# Altium schematic sheet OpenThereminUNO2.0.SchDoc
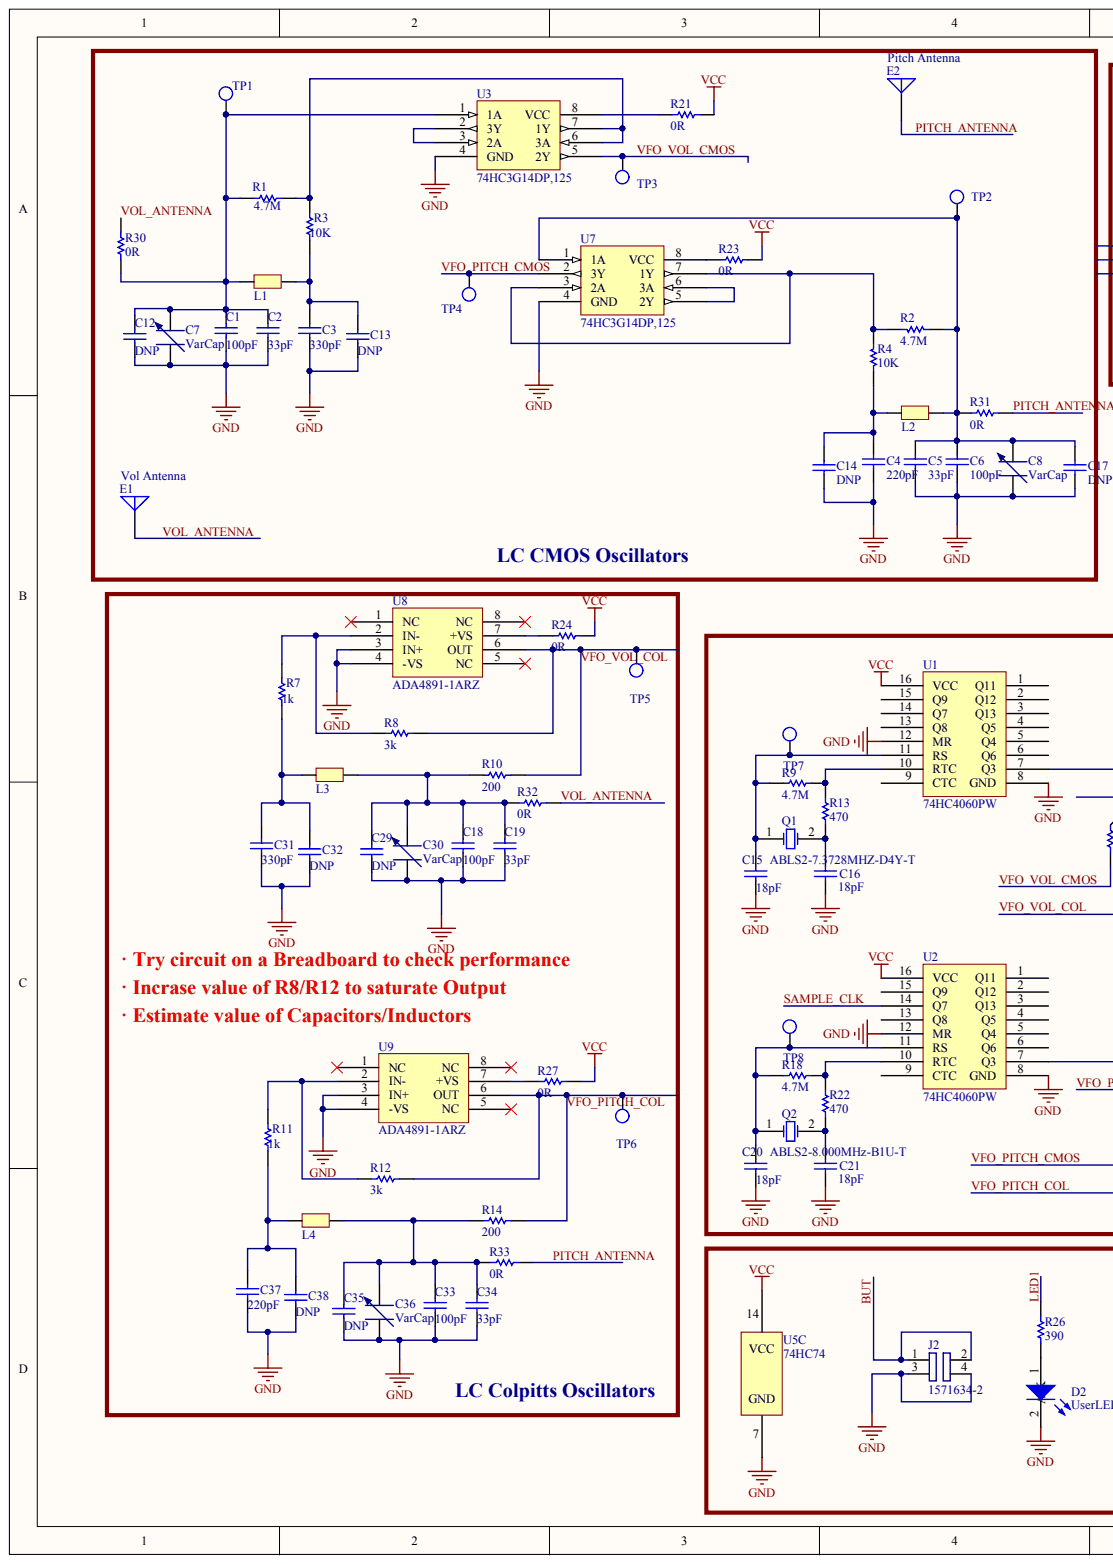
[diagram p0: OpenThereminUNO2.0.SchDoc, left]
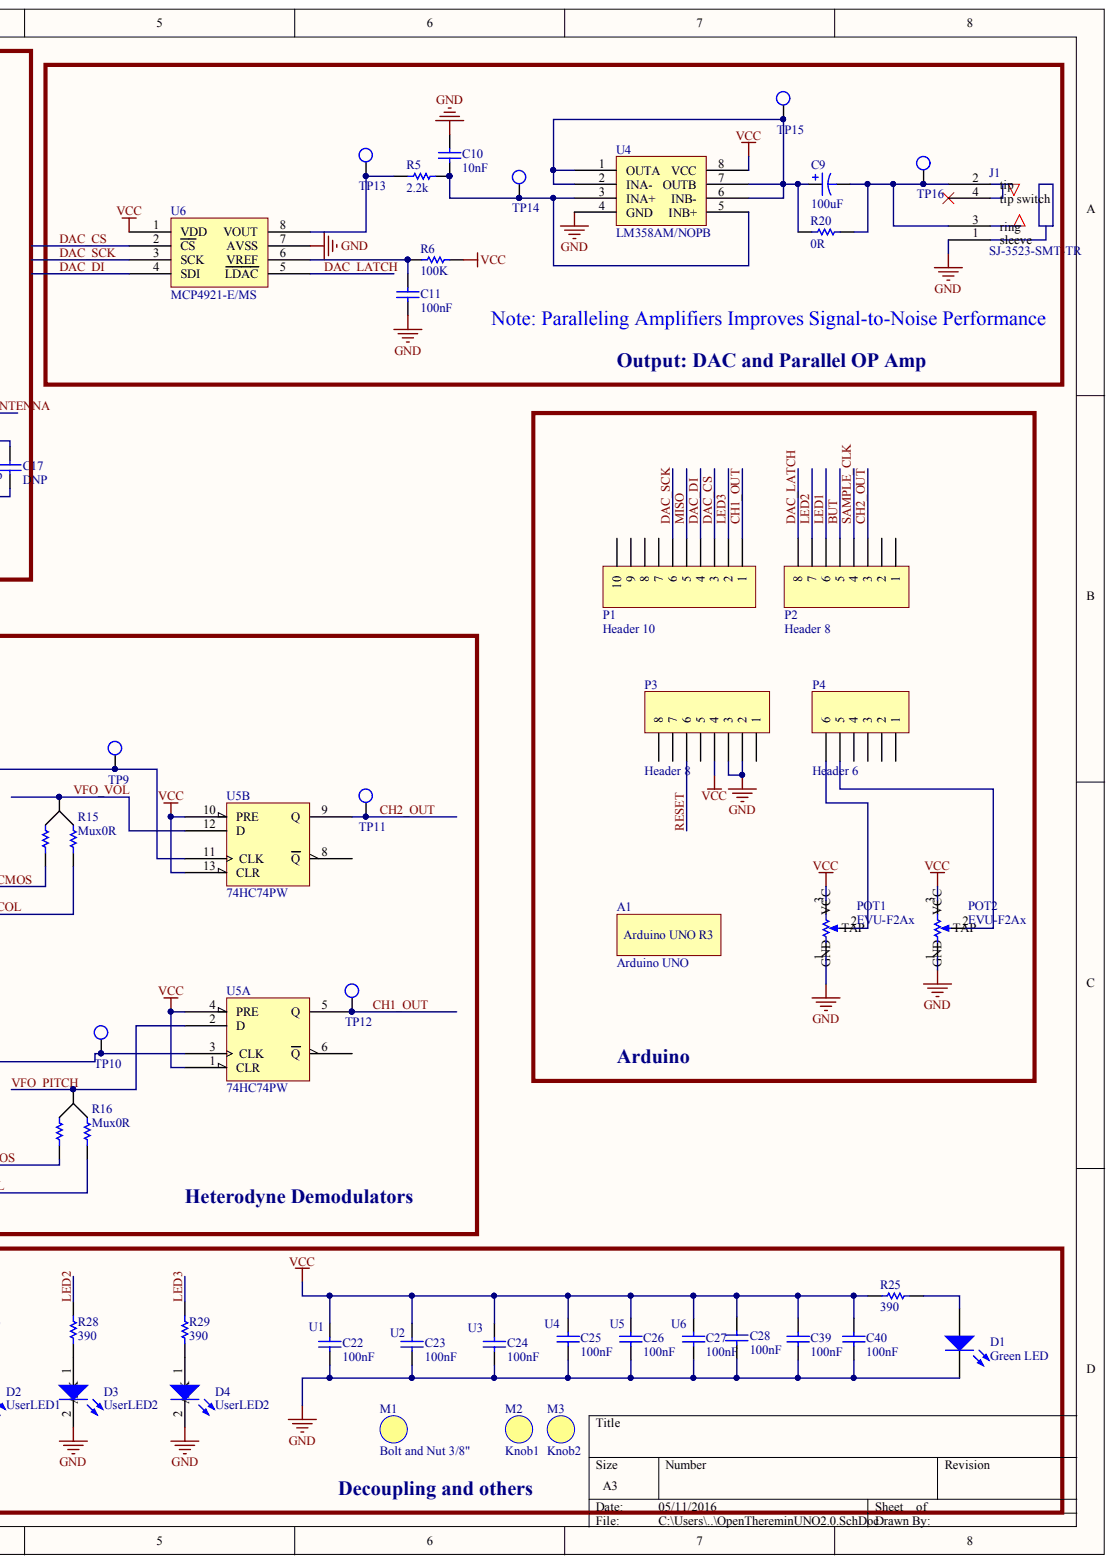
[diagram p1: OpenThereminUNO2.0.SchDoc, right]

## Parts
A1  Arduino UNO  [ArduinoUNO]
C1  value 100pF  [0603_CAP]  (hidden comment: Cap)
C2  value 33pF  [0603_CAP]  (hidden comment: Cap)
C3  value 330pF  [0603_CAP]  (hidden comment: Cap)
C4  value 220pF  [0603_CAP]  (hidden comment: Cap)
C5  value 33pF  [0603_CAP]  (hidden comment: Cap)
C6  value 100pF  [0603_CAP]  (hidden comment: Cap)
C7  VarCap  [VarCapacitor]
C8  VarCap  [VarCapacitor]
C9  value 100uF  [TANTALUM_CAP]  (hidden comment: Cap Pol1)
C10  value 10nF  [0603_CAP]  (hidden comment: Cap)
C11  value 100nF  [0603_CAP]  (hidden comment: Cap)
C12  value DNP  [0603_CAP]  (hidden comment: Cap)
C13  value DNP  [0603_CAP]  (hidden comment: Cap)
C14  value DNP  [0603_CAP]  (hidden comment: Cap)
C15  value 18pF  [0603_CAP]  (hidden comment: Cap)
C16  value 18pF  [0603_CAP]  (hidden comment: Cap)
C17  value DNP  [0603_CAP]  (hidden comment: Cap)
C18  value 100pF  [0603_CAP]  (hidden comment: Cap)
C19  value 33pF  [0603_CAP]  (hidden comment: Cap)
C20  value 18pF  [0603_CAP]  (hidden comment: Cap)
C21  value 18pF  [0603_CAP]  (hidden comment: Cap)
C22  value 100nF  [0603_CAP]  (hidden comment: Cap)
C23  value 100nF  [0603_CAP]  (hidden comment: Cap)
C24  value 100nF  [0603_CAP]  (hidden comment: Cap)
C25  value 100nF  [0603_CAP]  (hidden comment: Cap)
C26  value 100nF  [0603_CAP]  (hidden comment: Cap)
C27  value 100nF  [0603_CAP]  (hidden comment: Cap)
C28  value 100nF  [0603_CAP]  (hidden comment: Cap)
C29  value DNP  [0603_CAP]  (hidden comment: Cap)
C30  VarCap  [VarCapacitor]
C31  value 330pF  [0603_CAP]  (hidden comment: Cap)
C32  value DNP  [0603_CAP]  (hidden comment: Cap)
C33  value 100pF  [0603_CAP]  (hidden comment: Cap)
C34  value 33pF  [0603_CAP]  (hidden comment: Cap)
C35  value DNP  [0603_CAP]  (hidden comment: Cap)
C36  VarCap  [VarCapacitor]
C37  value 220pF  [0603_CAP]  (hidden comment: Cap)
C38  value DNP  [0603_CAP]  (hidden comment: Cap)
C39  value 100nF  [0603_CAP]  (hidden comment: Cap)
C40  value 100nF  [0603_CAP]  (hidden comment: Cap)
D1  Green LED  [LED]
D2  UserLED1  [LED]
D3  UserLED2  [LED]
D4  UserLED2  [LED]
E1  Vol Antenna  [ANTENNA]
E2  Pitch Antenna  [ANTENNA]
J1  SJ-3523-SMT-TR  [SJ-352x-SMT-Series]
J2  1571634-2  [BUTTON_SMD]
L1  Inductor  [INDUCTOR_BADFP]
L2  Inductor  [INDUCTOR_BADFP]
L3  Inductor  [INDUCTOR_BADFP]
L4  Inductor  [INDUCTOR_BADFP]
M1  Bolt and Nut 3/8"  [BOLT_NUT_3_8]
M2  Knob1  [KNOB]
M3  Knob2  [KNOB]
P1  Header 10  [HEADER1X10]
P2  Header 8  [HEADER1X8]
P3  Header 8  [HEADER1X8]
P4  Header 6  [HEADER1X6]
POT1  EVU-F2Ax  [EVUF2A]
POT2  EVU-F2Ax  [EVUF2A]
Q1  ABLS2-7.3728MHZ-D4Y-T  [SMD_CRYSTAL]
Q2  ABLS2-8.000MHz-B1U-T  [SMD_CRYSTAL]
R1  value 4.7M  [0603_RES]  (hidden comment: Res1)
R2  value 4.7M  [0603_RES]  (hidden comment: Res1)
R3  value 10K  [0603_RES]  (hidden comment: Res1)
R4  value 10K  [0603_RES]  (hidden comment: Res1)
R5  value 2.2k  [0603_RES]  (hidden comment: Res1)
R6  value 100K  [0603_RES]  (hidden comment: Res1)
R7  value 1k  [0603_RES]  (hidden comment: Res1)
R8  value 3k  [0603_RES]  (hidden comment: Res1)
R9  value 4.7M  [0603_RES]  (hidden comment: Res1)
R10  value 200  [0603_RES]  (hidden comment: Res1)
R11  value 1k  [0603_RES]  (hidden comment: Res1)
R12  value 3k  [0603_RES]  (hidden comment: Res1)
R13  value 470  [0603_RES]  (hidden comment: Res1)
R14  value 200  [0603_RES]  (hidden comment: Res1)
R15  Mux0R  [MUX0R]
R16  Mux0R  [MUX0R]
R18  value 4.7M  [0603_RES]  (hidden comment: Res1)
R20  value 0R  [0603_RES]  (hidden comment: Res1)
R21  value 0R  [0603_RES]  (hidden comment: Res1)
R22  value 470  [0603_RES]  (hidden comment: Res1)
R23  value 0R  [0603_RES]  (hidden comment: Res1)
R24  value 0R  [0603_RES]  (hidden comment: Res1)
R25  value 390  [0603_RES]  (hidden comment: Res1)
R26  value 390  [0603_RES]  (hidden comment: Res1)
R27  value 0R  [0603_RES]  (hidden comment: Res1)
R28  value 390  [0603_RES]  (hidden comment: Res1)
R29  value 390  [0603_RES]  (hidden comment: Res1)
R30  value 0R  [0603_RES]  (hidden comment: Res1)
R31  value 0R  [0603_RES]  (hidden comment: Res1)
R32  value 0R  [0603_RES]  (hidden comment: Res1)
R33  value 0R  [0603_RES]  (hidden comment: Res1)
TP1  TestPoint  [TEST_POINT_VIA]  (value not drawn)
TP2  TestPoint  [TEST_POINT_VIA]  (value not drawn)
TP3  TestPoint  [TEST_POINT_VIA]  (value not drawn)
TP4  TestPoint  [TEST_POINT_VIA]  (value not drawn)
TP5  TestPoint  [TEST_POINT_VIA]  (value not drawn)
TP6  TestPoint  [TEST_POINT_VIA]  (value not drawn)
TP7  TestPoint  [TEST_POINT_VIA]  (value not drawn)
TP8  TestPoint  [TEST_POINT_VIA]  (value not drawn)
TP9  TestPoint  [TEST_POINT_VIA]  (value not drawn)
TP10  TestPoint  [TEST_POINT_VIA]  (value not drawn)
TP11  TestPoint  [TEST_POINT_VIA]  (value not drawn)
TP12  TestPoint  [TEST_POINT_VIA]  (value not drawn)
TP13  TestPoint  [TEST_POINT_VIA]  (value not drawn)
TP14  TestPoint  [TEST_POINT]  (value not drawn)
TP15  TestPoint  [TEST_POINT]  (value not drawn)
TP16  TestPoint  [TEST_POINT]  (value not drawn)
U1  74HC4060PW  [74HC4060_TSSOP16]
U2  74HC4060PW  [74HC4060_TSSOP16]
U3  74HC3G14DP,125  [74HC3G14DP,125]
U4  LM358AM/NOPB  [LM358_SOIC8]
U5  74HC74PW  [74HC74_TSSOP14]  (parts A,B,C)
U6  MCP4921-E/MS  [MC4921_MSOP]
U7  74HC3G14DP,125  [74HC3G14DP,125]
U8  ADA4891-1ARZ  [ADA4891-1]
U9  ADA4891-1ARZ  [ADA4891-1]

## Nets
GND: C1.1 C2.1 C3.1 C4.1 C5.1 C6.1 C7.1 C8.1 C10.2 C11.1 C12.1 C13.1 C14.1 C15.1 C16.1 C17.1 C18.1 C19.1 C20.1 C21.1 C22.1 C23.1 C24.1 C25.1 C26.1 C27.1 C28.1 C29.1 C30.1 C31.1 C32.1 C33.1 C34.1 C35.1 C36.1 C37.1 C38.1 C39.1 C40.1 D1.2 D2.2 D3.2 D4.2 J1.1(sleeve) J2.3 J2.4 P3.2 P3.3 POT1.1 POT2.1 U1.8(GND) U1.12(MR) U2.8(GND) U2.12(MR) U3.4(GND) U4.4(GND) U5.7 U6.7(AVSS) U7.4(GND) U8.3(IN+) U8.4(-VS) U9.3(IN+) U9.4(-VS)
VCC: C22.2 C23.2 C24.2 C25.2 C26.2 C27.2 C28.2 C39.2 C40.2 P3.4 POT1.3 POT2.3 R6.1 R21.1 R23.1 R24.1 R25.1 R27.1 U1.16(VCC) U2.16(VCC) U4.8(VCC) U5.1(CLR) U5.4(PRE) U5.10(PRE) U5.13(CLR) U5.14 U6.1(VDD)
BUT: J2.1 J2.2 P2.5
CH1_OUT: P1.1 TP12.1 U5.5(Q)
CH2_OUT: P2.3 TP11.1 U5.9(Q)
DAC_CS: P1.3 U6.2(CS)
DAC_DI: P1.4 U6.4(SDI)
DAC_LATCH: P2.8 U6.5(LDAC)
DAC_SCK: P1.6 U6.3(SCK)
LED1: P2.6 R26.2
LED2: P2.7 R28.2
LED3: P1.2 R29.2
MISO: P1.5
PITCH_ANTENNA: E2.1 R31.1 R33.1
RESET: P3.6
SAMPLE_CLK: P2.4 U2.14(Q7)
VFO_PITCH: R16.3 U5.2(D)
VFO_PITCH_CMOS: R16.1 TP4.1 U7.2(3Y)
VFO_PITCH_COL: R12.2 R14.2 R16.2 TP6.1 U9.6(OUT)
VFO_VOL: R15.3 U5.12(D)
VFO_VOL_CMOS: R15.1 TP3.1 U3.5(2Y)
VFO_VOL_COL: R8.2 R10.2 R15.2 TP5.1 U8.6(OUT)
VOL_ANTENNA: E1.1 R30.1 R32.1
NetC1_2: C1.2 C2.2 C7.2 C12.2 L1.1 R1.1 R30.2 TP1.1 U3.1(1A)
NetC3_2: C3.2 C13.2 L1.2 R3.1
NetC4_2: C4.2 C14.2 L2.1 R4.1
NetC5_2: C5.2 C6.2 C8.2 C17.2 L2.2 R2.1 R31.2 TP2.1 U7.1(1A)
NetC9_1: C9.1 R20.2 TP15.1 U4.1(OUTA) U4.2(INA-) U4.6(INB-) U4.7(OUTB)
NetC9_2: C9.2 J1.2(tip) J1.3(ring) R20.1 TP16.1
NetC10_1: C10.1 R5.2 TP14.1 U4.3(INA+) U4.5(INB+)
NetC11_2: C11.2 R6.2 U6.6(VREF)
NetC15_2: C15.2 Q1.1 R9.2 TP7.1 U1.11(RS)
NetC16_2: C16.2 Q1.2 R13.1
NetC18_2: C18.2 C19.2 C29.2 C30.2 L3.1 R10.1 R32.2
NetC20_2: C20.2 Q2.1 R18.2 TP8.1 U2.11(RS)
NetC21_2: C21.2 Q2.2 R22.1
NetC31_2: C31.2 C32.2 L3.2 R7.1
NetC33_2: C33.2 C34.2 C35.2 C36.2 L4.1 R14.1 R33.2
NetC37_2: C37.2 C38.2 L4.2 R11.1
NetD1_1: D1.1 R25.2
NetD2_1: D2.1 R26.1
NetD3_1: D3.1 R28.1
NetD4_1: D4.1 R29.1
NetP4_5: P4.5 POT2.2
NetP4_6: P4.6 POT1.2
NetR1_2: R1.2 R3.2 U3.6(3A) U3.7(1Y)
NetR2_2: R2.2 R4.2 U7.3(2A) U7.7(1Y)
NetR5_1: R5.1 TP13.1 U6.8(VOUT)
NetR7_2: R7.2 R8.1 U8.2(IN-)
NetR9_1: R9.1 R13.2 U1.10(RTC)
NetR11_2: R11.2 R12.1 U9.2(IN-)
NetR18_1: R18.1 R22.2 U2.10(RTC)
NetR21_2: R21.2 U3.8(VCC)
NetR23_2: R23.2 U7.8(VCC)
NetR24_2: R24.2 U8.7(+VS)
NetR27_2: R27.2 U9.7(+VS)
NetTP9_1: TP9.1 U1.7(Q3) U5.11(CLK)
NetTP10_1: TP10.1 U2.7(Q3) U5.3(CLK)
NetU3_2: U3.2(3Y) U3.3(2A)
NetU7_5: U7.5(2Y) U7.6(3A)

Not connected: J1 4(tip_switch); P1 7 8 9 10; P2 1 2; P3 1 5 7 8; P4 1 2 3 4; U1 1(Q11) 2(Q12) 3(Q13) 4(Q5) 5(Q4) 6(Q6) 9(CTC) 13(Q8) 14(Q7) 15(Q9); U2 1(Q11) 2(Q12) 3(Q13) 4(Q5) 5(Q4) 6(Q6) 9(CTC) 13(Q8) 15(Q9); U5 6(Q) 8(Q); U8 1(NC) 5(NC) 8(NC); U9 1(NC) 5(NC) 8(NC)

## Library data of the parts
A1 = ArduinoUNO_R3: Arduino Uno R3; Component Kind: Standard; Library Name: ThereminLib.SchLib
C1-C6 C10-C29 C31-C35 C37-C40 = Cap: Capacitor; Component Kind: Standard; LatestRevisionDate: 17-Jul-2002; LatestRevisionNote: Re-released for DXP Platform.; Library Name: Miscellaneous Devices.IntLib; PackageDescription: Radial Cap, Thru-Hole; 2 Leads; 0.3 in Pin Spacing; PackageReference: RAD-0.3; Published: 8-Jun-2000; Signal Integrity: Cap; Simulation: CAP; Publisher: Altium Limited
C7 C8 C30 C36 = VarCapacitor: Calibration Variable Capacitor; Component Kind: Standard; Library Name: ThereminLib.SchLib
C9 = Cap Pol1: Polarized Capacitor (Radial); Component Kind: Standard; LatestRevisionDate: 17-Jul-2002; LatestRevisionNote: Re-released for DXP Platform.; Library Name: Miscellaneous Devices.IntLib; PackageDescription: Polarized Capacitor (Radial); 2 Leads; PackageReference: RB7.6-15; Published: 8-Jun-2000; Publisher: Altium Limited
D1-D4 = LED1: Typical RED GaAs LED; Component Kind: Standard; LatestRevisionDate: 08-Jul-2005; LatestRevisionNote: Mech Layer 1 removed.; Library Name: Miscellaneous Devices.IntLib; PackageDescription: LED; 2 Leads; PackageReference: LED-1; Published: 8-Jun-2000; Publisher: Altium Limited
  D1: Simulation: LED1
E1 E2 = Antenna: Generic Antenna; Component Kind: Standard; LatestRevisionDate: 17-Jul-2002; LatestRevisionNote: Re-released for DXP Platform.; Library Name: Miscellaneous Devices.IntLib; PackageReference: PIN1; Published: 24-Mar-1999; Publisher: Altium Limited
J1 = Jack4: SJ-3523 / SJ-3524* (preferred) SMD; Component Kind: Standard; Library Name: ThereminLib.SchLib
J2 = BUTTON_4pin: 4 pin SMD Button; Component Kind: Standard; Library Name: ThereminLib.SchLib
M1-M3 = Mechanical: Misc Mechanical Parts; Component Kind: Standard; Library Name: ThereminLib.SchLib
P1 = Header 10: Header, 10-Pin; Component Kind: Standard; LatestRevisionDate: 17-Jul-2002; LatestRevisionNote: Re-released for DXP Platform.; Library Name: Miscellaneous Connectors.IntLib; Publisher: Altium Limited
P2 P3 = Header 8: Header, 8-Pin; Component Kind: Standard; LatestRevisionDate: 17-Jul-2002; LatestRevisionNote: Re-released for DXP Platform.; Library Name: Miscellaneous Connectors.IntLib; Publisher: Altium Limited
P4 = Header 6: Header, 6-Pin; Component Kind: Standard; LatestRevisionDate: 17-Jul-2002; LatestRevisionNote: Re-released for DXP Platform.; Library Name: Miscellaneous Connectors.IntLib; Publisher: Altium Limited
POT1 POT2 = EVU-F2Ax: Potentiometer; Component Kind: Standard; Library Name: ThereminLib.SchLib
Q1 Q2 = XTAL: Crystal Oscillator; Component Kind: Standard; LatestRevisionDate: 17-Jul-2002; LatestRevisionNote: Re-released for DXP Platform.; Library Name: Miscellaneous Devices.IntLib; PackageDescription: Crystal, Thru-Hole; 2 Leads; Body 3.1 x 8.2 mm (Dia.xH); PackageReference: R38; Published: 8-Jun-2000; Publisher: Altium Limited
  Q2: Simulation: XTAL
R1-R14 R18 R20-R33 = Res1: Resistor; Component Kind: Standard; LatestRevisionDate: 17-Jul-2002; LatestRevisionNote: Re-released for DXP Platform.; Library Name: Miscellaneous Devices.IntLib; PackageDescription: Axial Device, Thru-Hole; 2 Leads; 0.3 in Pin Spacing; PackageReference: AXIAL-0.3; Published: 8-Jun-2000; Signal Integrity: Res; Simulation: RESISTOR; Publisher: Altium Limited
R15 R16 = Mux0R: 3-terminals 0R Mux; Component Kind: Standard; Library Name: ThereminLib.SchLib
TP1-TP16 = TestPoint: Test Point Via-Like for Oscilloscope Probing; Component Kind: Standard; Library Name: ThereminLib.SchLib
U1 U2 = 74HC4060: 14-stage binary rippple counter with oscillator; Component Kind: Standard; Library Name: ThereminLib.SchLib
U3 U7 = 74HC3G14DP,125: Inverters 5V TRIPLE INV SCHMTT; Component Kind: Standard; Library Name: ThereminLib.SchLib
U4 = LM358: Low Power Dual Operational Amplifiers; Component Kind: Standard; Library Name: ThereminLib.SchLib
U5 = 74HC74: Dual D-type flip-flop with set and reset; positive edge trigger; Component Kind: Standard; Library Name: ThereminLib.SchLib
U6 = MCP4921: 12-Bit DAC with SPI Interface; Component Kind: Standard; Library Name: ThereminLib.SchLib
U8 U9 = ADA4891-1: Low Cost CMOS, High Speed,  Rail-to-Rail Amplifiers; Component Kind: Standard; Library Name: ThereminLib.SchLib
L1-L4 = Inductor: Component Kind: Standard; Description: Inductor; Library Name: ThereminLib.SchLib
